# Altium schematic sheet GammaMRICAB_2 - Emission_b.SchDoc
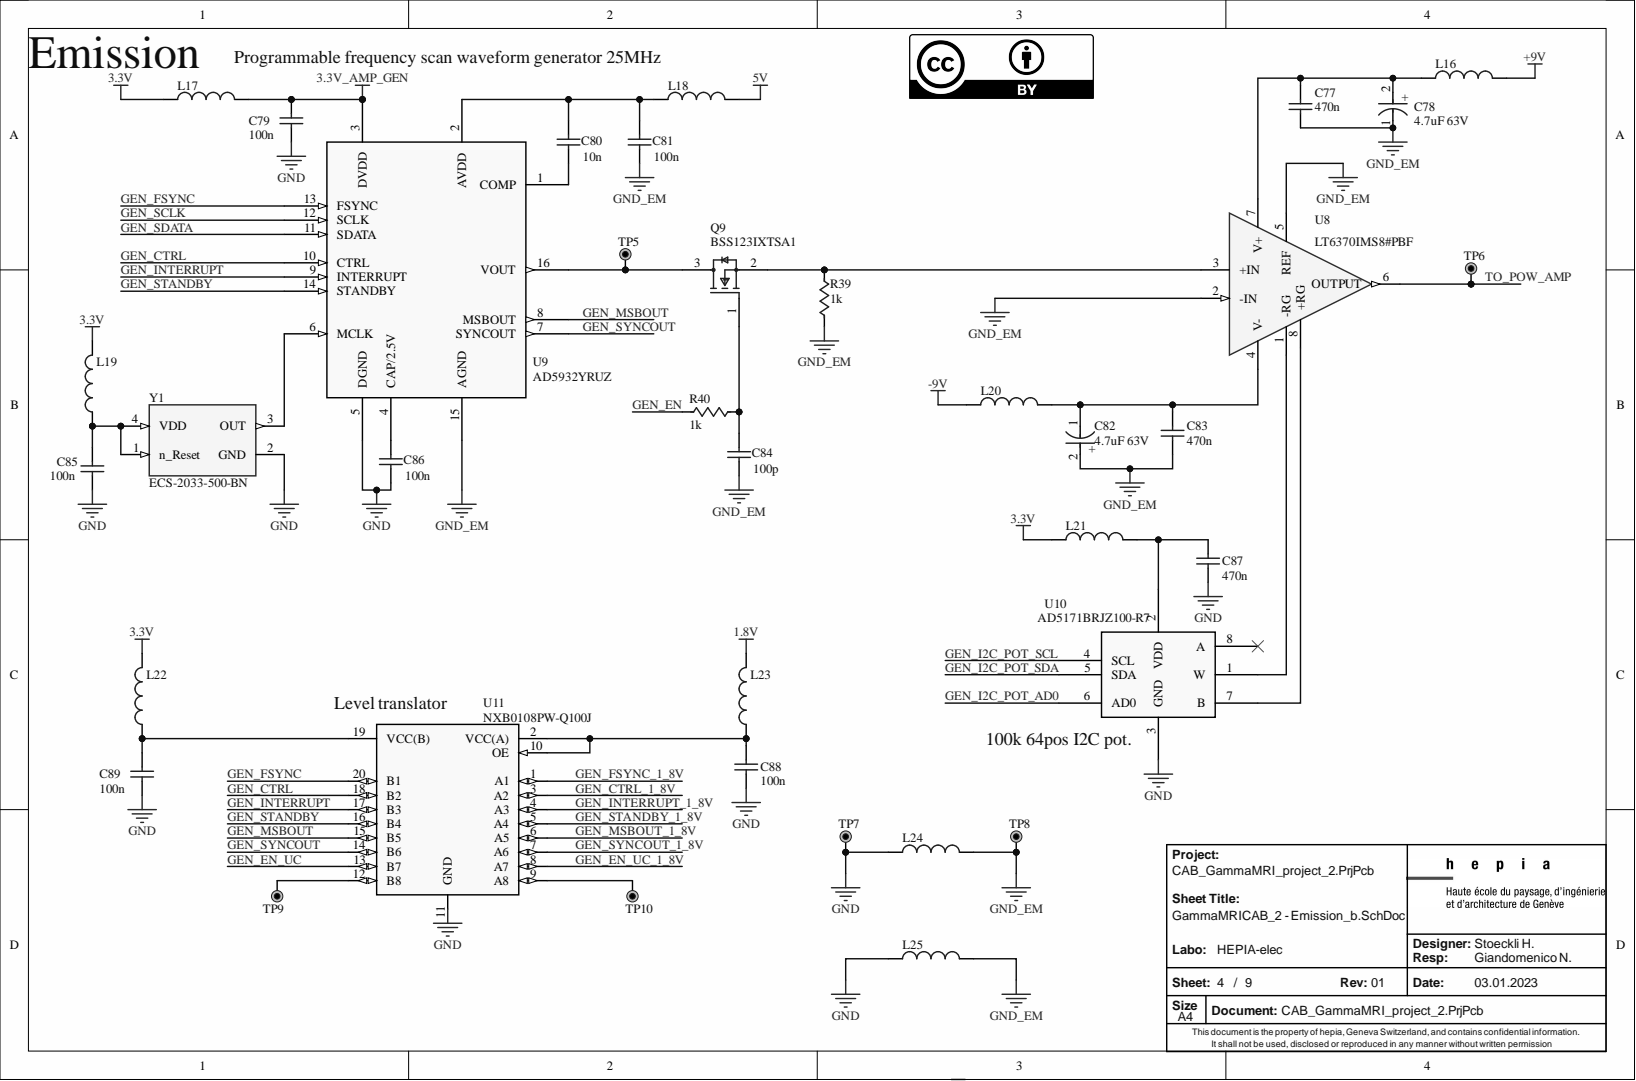
[diagram p0: GammaMRICAB_2 - Emission_b.SchDoc]

## Parts
C77  470n  [1608[0603]]
C78  4.7uF 63V  [FP-TCJC-MFG]
C79  100n  [1608[0603]]
C80  10n  [0402]
C81  100n  [1608[0603]]
C82  4.7uF 63V  [FP-TCJC-MFG]
C83  470n  [1608[0603]]
C84  100p  [1608[0603]]
C85  100n  [1608[0603]]
C86  100n  [1608[0603]]
C87  470n  [0402]
C88  100n  [0402]
C89  100n  [0402]
L16  BKP0603HS121-T  [1608[0603]]  (value not drawn)
L17  BKP0603HS121-T  [1608[0603]]  (value not drawn)
L18  BKP0603HS121-T  [1608[0603]]  (value not drawn)
L19  BKP0603HS121-T  [1608[0603]]  (value not drawn)
L20  BKP0603HS121-T  [1608[0603]]  (value not drawn)
L21  BKP0603HS121-T  [1608[0603]]  (value not drawn)
L22  BKP0603HS121-T  [1608[0603]]  (value not drawn)
L23  BKP0603HS121-T  [1608[0603]]  (value not drawn)
L24  BKP0603HS121-T  [1608[0603]]  (value not drawn)
L25  BKP0603HS121-T  [1608[0603]]  (value not drawn)
Q9  BSS123IXTSA1  [FP-PG-SOT23-3-IPC_A]
R39  1k  [1608[0603]]
R40  1k  [1608[0603]]
TP5  TP_PAD_Hole  [TP_PAD_Hole]  (value not drawn)
TP6  TP_PAD_Hole  [TP_PAD_Hole]  (value not drawn)
TP7  TP_PAD_Hole  [TP_PAD_Hole]  (value not drawn)
TP8  TP_PAD_Hole  [TP_PAD_Hole]  (value not drawn)
TP9  TP_PAD_Hole  [TP_PAD_Hole]  (value not drawn)
TP10  TP_PAD_Hole  [TP_PAD_Hole]  (value not drawn)
U8  LT6370IMS8#PBF  [LT6370-FP-MS8-8-05-08-1660-IPC_A]
U9  AD5932YRUZ  [AD5932]
U10  AD5171BRJZ100-R7  [RJ_8_ADI-L]
U11  NXB0108PW-Q100J  [TSSOP20_SOT360-1_NEX-M]
Y1  ECS-2033-500-BN  [OSC_ECS-2033-500-BN]

## Nets
1.8V: L23.2
3.3V: L17.1 L19.2 L21.1 L22.2
3.3V_AMP_GEN: C79.2 L17.2 U9.3(DVDD)
5V: L18.2
+9V: L16.1
-9V: L20.1
GND: C79.1 C85.1 C86.1 C87.1 C88.1 C89.1 L24.1 L25.1 TP7.1 U9.5(DGND) U10.3(GND) U11.11(GND) Y1.2(GND)
GND_EM: C77.1 C78.1 C81.1 C82.2 C83.1 C84.1 L24.2 L25.2 R39.1 TP8.1 U8.2(-IN) U8.5(REF) U9.15(AGND)
GEN_CTRL: U9.10(CTRL) U11.18(B2)
GEN_CTRL_1_8V: U11.3(A2)
GEN_EN: R40.1
GEN_EN_UC: U11.13(B7)
GEN_EN_UC_1_8V: U11.8(A7)
GEN_FSYNC: U9.13(FSYNC) U11.20(B1)
GEN_FSYNC_1_8V: U11.1(A1)
GEN_I2C_POT_AD0: U10.6(AD0)
GEN_I2C_POT_SCL: U10.4(SCL)
GEN_I2C_POT_SDA: U10.5(SDA)
GEN_INTERRUPT: U9.9(INTERRUPT) U11.17(B3)
GEN_INTERRUPT_1_8V: U11.4(A3)
GEN_MSBOUT: U9.8(MSBOUT) U11.15(B5)
GEN_MSBOUT_1_8V: U11.6(A5)
GEN_SCLK: U9.12(SCLK)
GEN_SDATA: U9.11(SDATA)
GEN_STANDBY: U9.14(STANDBY) U11.16(B4)
GEN_STANDBY_1_8V: U11.5(A4)
GEN_SYNCOUT: U9.7(SYNCOUT) U11.14(B6)
GEN_SYNCOUT_1_8V: U11.7(A6)
TO_POW_AMP: TP6.1 U8.6(OUTPUT)
NetC77_2: C77.2 C78.2 L16.2 U8.7(V+)
NetC80_1: C80.1 U9.1(COMP)
NetC80_2: C80.2 C81.2 L18.1 U9.2(AVDD)
NetC82_1: C82.1 C83.2 L20.2 U8.4(V-)
NetC84_2: C84.2 Q9.1 R40.2
NetC85_2: C85.2 L19.1 Y1.1(n_Reset) Y1.4(VDD)
NetC86_2: C86.2 U9.4(CAP/2.5V)
NetC87_2: C87.2 L21.2 U10.2(VDD)
NetC88_2: C88.2 L23.1 U11.2(VCC(A)) U11.10(OE)
NetC89_2: C89.2 L22.1 U11.19(VCC(B))
NetQ9_2: Q9.2 R39.2 U8.3(+IN)
NetQ9_3: Q9.3 TP5.1 U9.16(VOUT)
NetTP9_1: TP9.1 U11.12(B8)
NetTP10_1: TP10.1 U11.9(A8)
NetU8_1: U8.1(-RG) U10.1(W)
NetU8_8: U8.8(+RG) U10.7(B)
NetU9_6: U9.6(MCLK) Y1.3(OUT)

Not connected: U10 8(A)

## Library data of the parts
C77 C83 = Cap: Capacitor 470nF C0603 X6S 50V; Component Kind: Standard; Library Name: Miscellaneous Devices.IntLib; Signal Integrity: Cap; Simulation: CAP; Supplier1: Digikey
C78 C82 = CMP-04423-000110-1: 4.7uF 63V  ±20% 200mΩ 2312  SMD Polymer Capacitor TCJC475M063R0200E; Component Kind: Standard; Library Name: Altium Content Vault; Supplier1: Digikey; Supplier1PN1: TCJC475M063R0200E
C79 C81 C85 C86 = Cap: CAP CER 0.1UF 50V Y5V 0603; Component Kind: Standard; Library Name: Miscellaneous Devices.IntLib; Signal Integrity: Cap; Simulation: CAP; Supplier1: Digikey; Supplier1PN1: 311-1343-1-ND
C80 = Cap: CAP CER 10000PF 50V X7R 0402; Component Kind: Standard; Library Name: Miscellaneous Devices.IntLib; Signal Integrity: Cap; Simulation: CAP; Supplier1: Digikey; Supplier1PN1: 490-4762-1-ND
C84 = Cap: CAP CER 100pF 50V Y5V 0603; Component Kind: Standard; Library Name: Miscellaneous Devices.IntLib; Signal Integrity: Cap; Simulation: CAP; Supplier1: Digikey
C87 = Cap: Capacitor 470nF C0402 X5R 6.3V; Component Kind: Standard; Library Name: Miscellaneous Devices.IntLib; Signal Integrity: Cap; Simulation: CAP; Supplier1: Digikey; Supplier1PN1: 311-1684-6-ND
C88 C89 = Cap: Capacitor 100nF C0402 X5R 6.3V; Component Kind: Standard; Library Name: Miscellaneous Devices.IntLib; Signal Integrity: Cap; Simulation: CAP; Supplier1: Digikey; Supplier1PN1: 490-6287-6-ND
L16-L25 = Inductor: FERRITE BEAD 120 OHM 0603(1608) 1LN; Component Kind: Standard; Library Name: Miscellaneous Devices.IntLib; Signal Integrity: Inductor; Simulation: INDUCTOR; Supplier1: Digikey; Supplier1PN1: 553-2387-1-ND
Q9 = BSS123IXTSA1: SMALL SIGNAL MOSFETS PG-SOT23-3; Component Kind: Standard; Supplier1: Digikey; Supplier1PN1: 448-BSS123IXTSA1DKR-ND; Library Name: CAB_SCH_LIB.SchLib
R39 R40 = D_RES-120: Thick Film Resistor, Boite Labo 0603, 1k 1%; Database Table Name: D_Resistors$; Component Kind: Standard; Supplier1: Digikey; Library Name: Database_Altium_Hepia_Elec.DbLib
U8 = LT6370: IC INST AMP 1 CIRCUIT 8MSOP; Component Kind: Standard; Supplier1: Digikey; Supplier1PN1: 161-LT6370IMS8#PBF-ND; Library Name: CAB_SCH_LIB.SchLib
U9 = AD5932YRUZ: IC DDS 50MHZ 10BIT 16TSSOP; Component Kind: Standard; Supplier1: Digikey; Supplier1PN1: AD5932YRUZ-ND; Library Name: CAB_SCH_LIB.SchLib
U10 = AD5171BRJZ10-R7: IC DGTL POT 10KOHM 64TAP SOT23-8; Component Kind: Standard; Supplier1: Digikey; Supplier1PN1: 505-AD5171BRJZ10-R7CT-ND; Library Name: CAB_SCH_LIB.SchLib
U11 = NXB0108PW-Q100J: No Description Available; Component Kind: Standard; Supplier1: Digikey; Supplier1PN1: 1727-NXB0108PW-Q100JCT-ND; Library Name: CAB_SCH_LIB.SchLib
Y1 = ECS-2033-500-BN: XTAL OSC XO 50.0000MHZ CMOS SMD; Component Kind: Standard; Supplier1: Digikey; Supplier1PN1: ECS-2033-500-BN; Library Name: CAB_SCH_LIB.SchLib
TP5-TP10 = TP_PAD_Hole: Component Kind: Standard; Library Name: CAB_SCH_LIB.SchLib
